# Altium schematic sheet P03_MCU.SchDoc
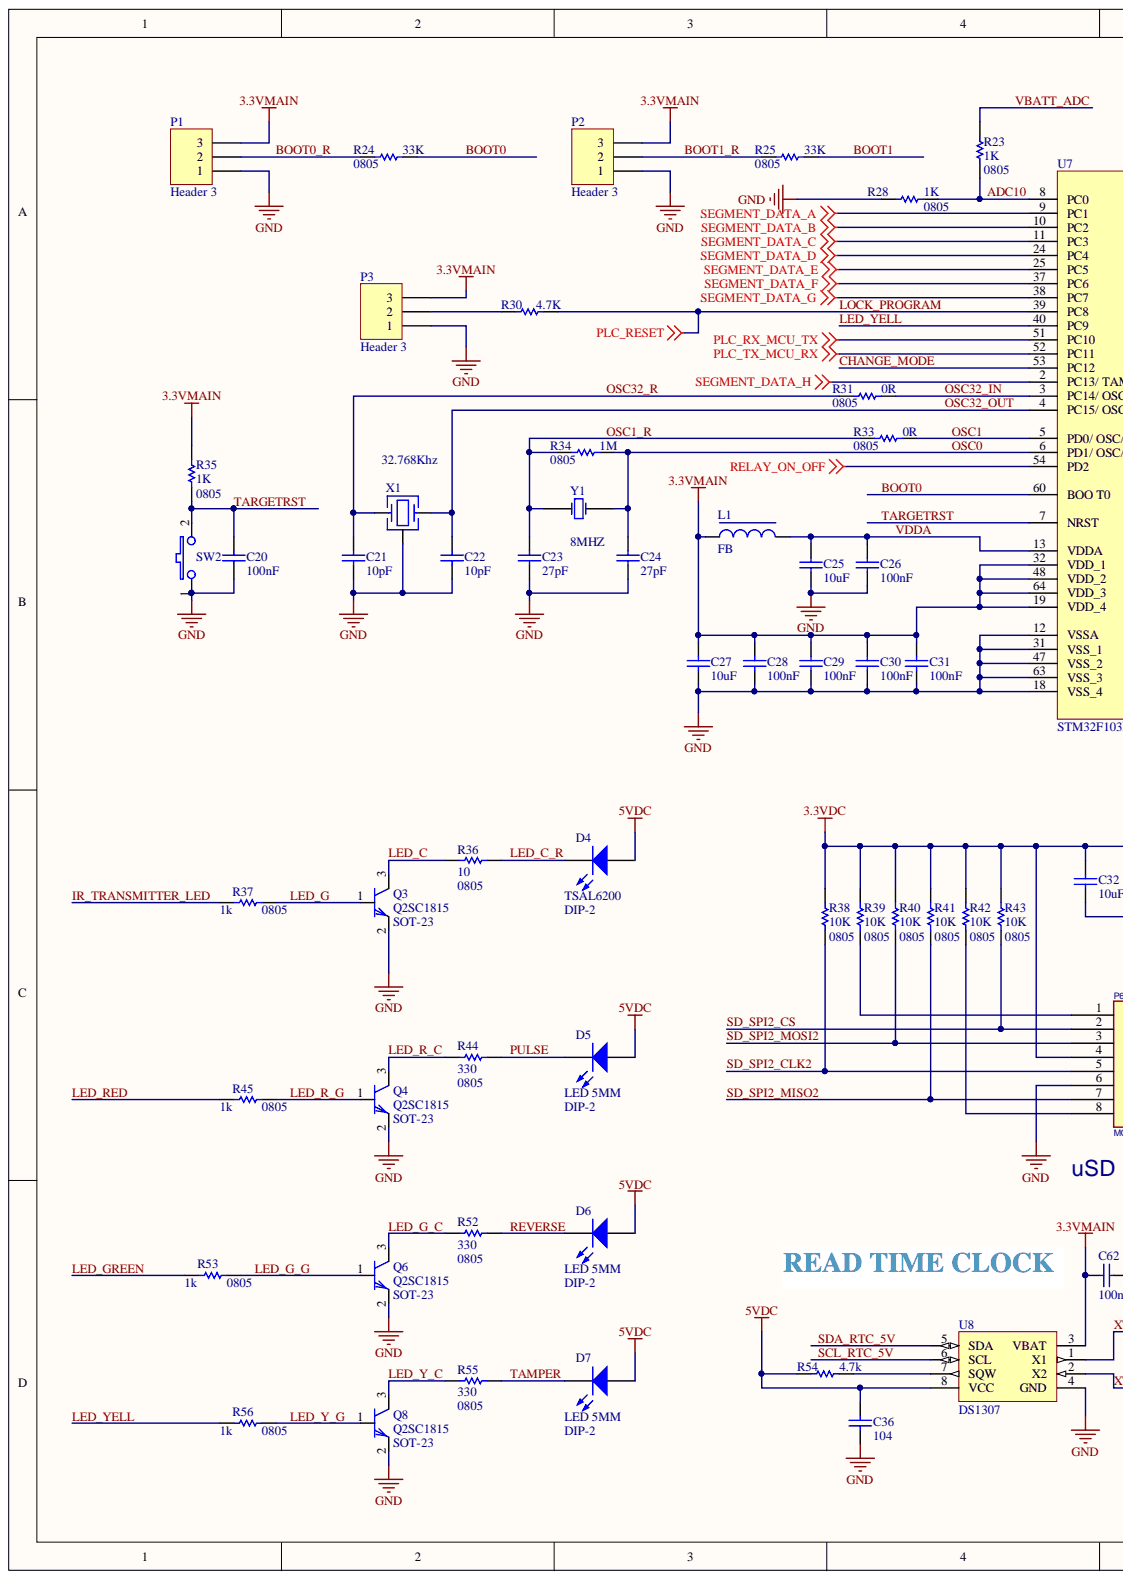
[diagram p0: P03_MCU.SchDoc, left]
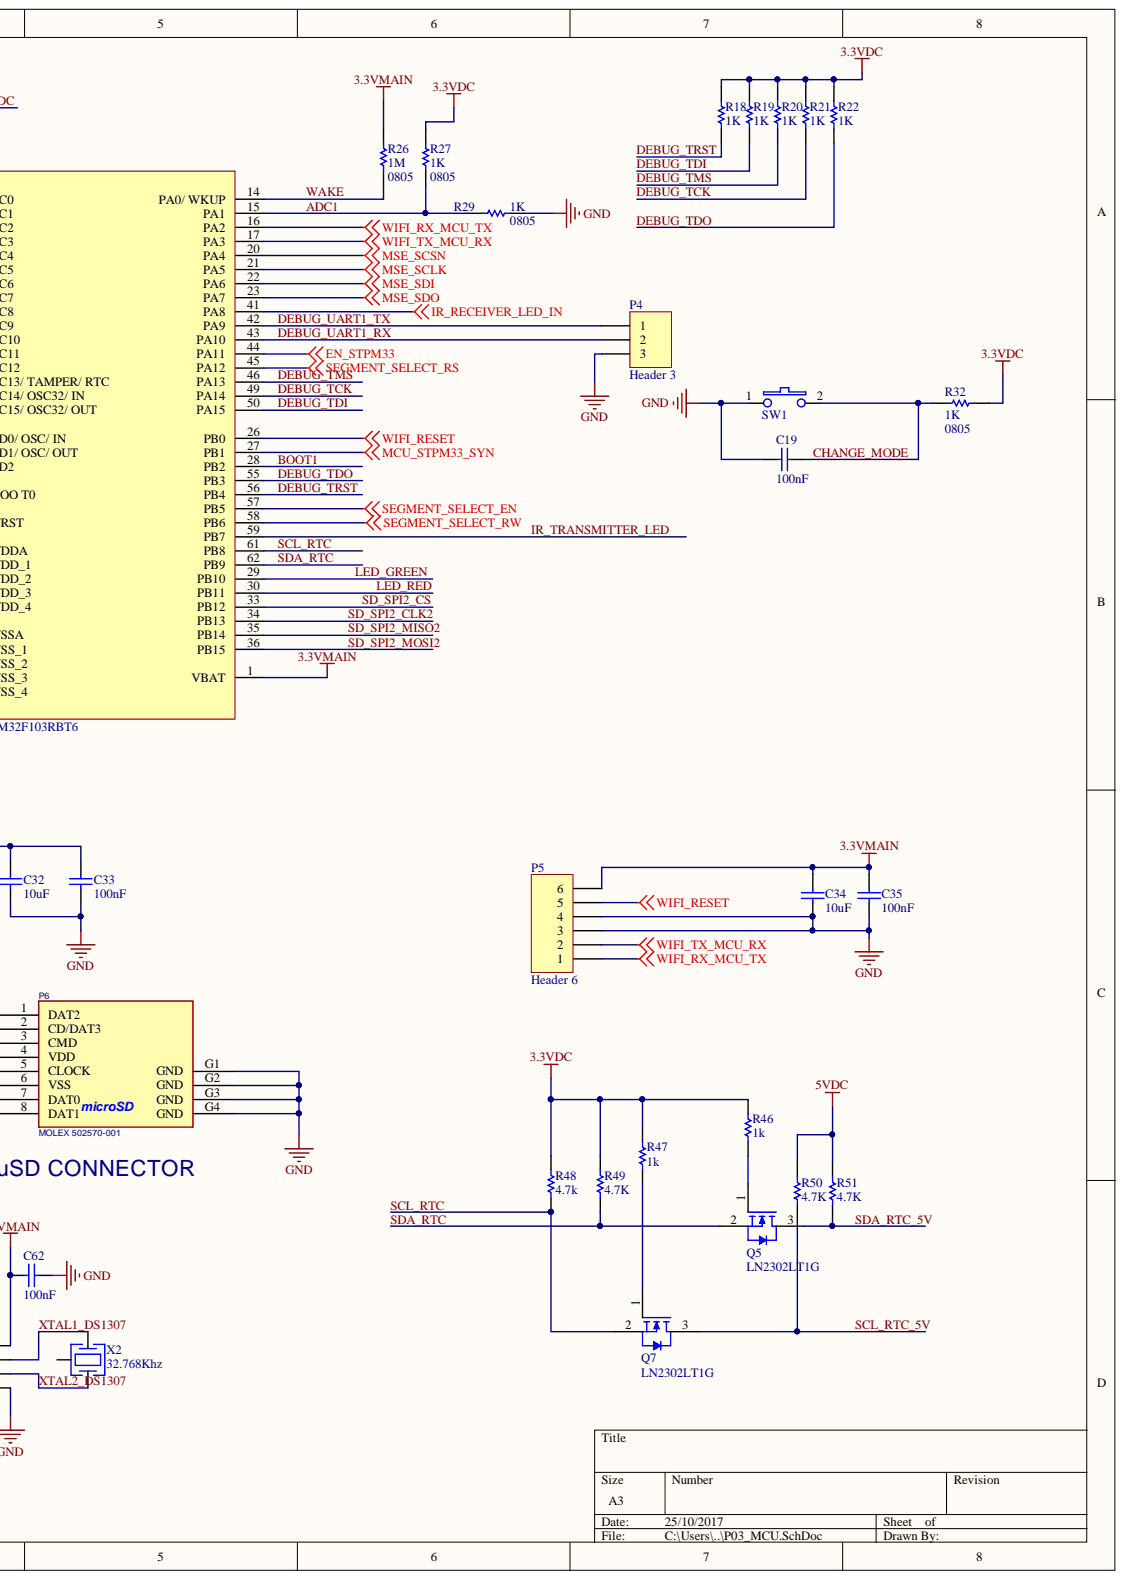
[diagram p1: P03_MCU.SchDoc, right]

## Parts
C19  100nF  [C-NP-0805]
C20  100nF  [C-NP-0805]
C21  10pF  [C-NP-0805]
C22  10pF  [C-NP-0805]
C23  27pF  [C-NP-0805]
C24  27pF  [C-NP-0805]
C25  10uF  [C-NP-0805]
C26  100nF  [C-NP-0805]
C27  10uF  [C-NP-0805]
C28  100nF  [C-NP-0805]
C29  100nF  [C-NP-0805]
C30  100nF  [C-NP-0805]
C31  100nF  [C-NP-0805]
C32  10uF  [C-NP-0805]
C33  100nF  [C-NP-0805]
C34  10uF  [C-NP-0805]
C35  100nF  [C-NP-0805]
C36  104  [C-NP-0805]
C62  100nF  [C-NP-0805]
D4  LED  Part: TSAL6200  PCB_Footprint: DIP-2  [LED-0 5mm BLUE]
D5  LED  Part: LED 5MM  PCB_Footprint: DIP-2  [LED-0 5mm RED]
D6  LED  Part: LED 5MM  PCB_Footprint: DIP-2  [LED-0 5mm ORANGE]
D7  LED  Part: LED 5MM  PCB_Footprint: DIP-2  [LED-0 5mm YELLOW]
L1  FB  [Res 10mm (1/2W)]
P1  Header 3  [Header 3]
P2  Header 3  [Header 3]
P3  Header 3  [Header 3]
P4  Header 3  [Header 3]
P5  Header 6  [Header 6]
P6  MOLEX 502570-001  [MicroSD_Socket]
Q3  C1815  [SOT-23]
Q4  C1815  [SOT-23]
Q5  LN2302LT1G  [SOT-23]
Q6  C1815  [SOT-23]
Q7  LN2302LT1G  [SOT-23]
Q8  C1815  [SOT-23]
R18  Part: 1K  [R-0805]  (hidden comment: 10k)
R19  1K  [R-0805]
R20  1K  [R-0805]
R21  1K  [R-0805]
R22  1K  [R-0805]
R23  1K  PCB_Footprint: 0805  [R-0805]
R24  33K  PCB_Footprint: 0805  [R-0805]
R25  33K  PCB_Footprint: 0805  [R-0805]
R26  1M  PCB_Footprint: 0805  [R-0805]
R27  1K  PCB_Footprint: 0805  [R-0805]
R28  1K  PCB_Footprint: 0805  [R-0805]
R29  1K  PCB_Footprint: 0805  [R-0805]
R30  4.7K  [R-0805]
R31  0R  PCB_Footprint: 0805  [R-0805]
R32  1K  PCB_Footprint: 0805  [R-0805]
R33  0R  PCB_Footprint: 0805  [R-0805]
R34  1M  PCB_Footprint: 0805  [R-0805]
R35  1K  PCB_Footprint: 0805  [R-0805]
R36  10  PCB_Footprint: 0805  [R-0805]
R37  1k  PCB_Footprint: 0805  [R-0805]
R38  10K  PCB_Footprint: 0805  [R-0805]
R39  10K  PCB_Footprint: 0805  [R-0805]
R40  10K  PCB_Footprint: 0805  [R-0805]
R41  10K  PCB_Footprint: 0805  [R-0805]
R42  10K  PCB_Footprint: 0805  [R-0805]
R43  10K  PCB_Footprint: 0805  [R-0805]
R44  330  PCB_Footprint: 0805  [R-0805]
R45  1k  PCB_Footprint: 0805  [R-0805]
R46  1k  [R-0805]
R47  1k  [R-0805]
R48  4.7k  [R-0805]
R49  4.7K  [R-0805]
R50  4.7K  [R-0805]
R51  4.7K  [R-0805]
R52  330  PCB_Footprint: 0805  [R-0805]
R53  1k  PCB_Footprint: 0805  [R-0805]
R54  4.7k  [R-0805]
R55  330  PCB_Footprint: 0805  [R-0805]
R56  1k  PCB_Footprint: 0805  [R-0805]
SW1  PTS635  [TactSwitches.PTS635.SMT]  (value not drawn)
SW2  PTS635  [TactSwitches.PTS635.SMT]  (value not drawn)
U7  STM32F103RBT6  [TQFP-PT64_N]
U8  DS1307  [SOIC8]
X1  32.768Khz  [Crystal_cylinder_SMD]
X2  32.768Khz  [Crystal_cylinder_SMD]
Y1  8MHZ  [CRYSTAL_SMD]

## Nets
3.3VDC: C32.2 C33.2 P6.4(VDD) R18.2 R19.2 R20.2 R21.2 R22.2 R27.2 R32.1 R38.1 R39.1 R40.1 R41.1 R42.1 R43.1 R46.2 R47.2 R48.1 R49.2
3.3VMAIN: C27.2 C28.2 C29.2 C30.2 C31.2 C34.2 C35.2 C62.2 L1.1 P1.3 P2.3 P3.3 P5.6 R26.1 R35.2 U7.1(VBAT) U7.19(VDD_4) U7.32(VDD_1) U7.48(VDD_2) U7.64(VDD_3) U8.3(VBAT)
5VDC: C36.1 D4.1 D5.1 D6.1 D7.1 R50.2 R51.2 R54.1 U8.8(VCC)
EN_STPM33: U7.44(PA11)
GND: C19.1 C20.2 C21.1 C22.1 C23.1 C24.1 C25.1 C26.1 C27.1 C28.1 C29.1 C30.1 C31.1 C32.1 C33.1 C34.1 C35.1 C36.2 C62.1 P1.1 P2.1 P3.1 P4.3 P5.3 P5.4 P6.6(VSS) P6.G1(GND) P6.G2(GND) P6.G3(GND) P6.G4(GND) Q3.2 Q4.2 Q6.2 Q8.2 R28.2 R29.1 SW1.1 SW2.1 U7.12(VSSA) U7.18(VSS_4) U7.31(VSS_1) U7.47(VSS_2) U7.63(VSS_3) U8.4(GND) X1.3
IR_RECEIVER_LED_IN: U7.41(PA8)
MCU_STPM33_SYN: U7.27(PB1)
MSE_SCLK: U7.21(PA5)
MSE_SCSN: U7.20(PA4)
MSE_SDI: U7.22(PA6)
MSE_SDO: U7.23(PA7)
PLC_RX_MCU_TX: U7.51(PC10)
PLC_TX_MCU_RX: U7.52(PC11)
RELAY_ON_OFF: U7.54(PD2)
SEGMENT_DATA_A: U7.9(PC1)
SEGMENT_DATA_B: U7.10(PC2)
SEGMENT_DATA_C: U7.11(PC3)
SEGMENT_DATA_D: U7.24(PC4)
SEGMENT_DATA_E: U7.25(PC5)
SEGMENT_DATA_F: U7.37(PC6)
SEGMENT_DATA_G: U7.38(PC7)
SEGMENT_DATA_H: U7.2(PC13/_TAMPER/_RTC)
SEGMENT_SELECT_EN: U7.57(PB5)
SEGMENT_SELECT_RS: U7.45(PA12)
SEGMENT_SELECT_RW: U7.58(PB6)
WIFI_RESET: P5.5 U7.26(PB0)
WIFI_RX_MCU_TX: P5.1 U7.16(PA2)
WIFI_TX_MCU_RX: P5.2 U7.17(PA3)
ADC1: R27.1 R29.2 U7.15(PA1)
ADC10: R23.1 R28.1 U7.8(PC0)
BOOT0: R24.2 U7.60(BOO_T0)
BOOT0_R: P1.2 R24.1
BOOT1: R25.2 U7.28(PB2)
BOOT1_R: P2.2 R25.1
CHANGE_MODE: C19.2 R32.2 SW1.2 U7.53(PC12)
DEBUG_TCK: R21.1 U7.49(PA14)
DEBUG_TDI: R19.1 U7.50(PA15)
DEBUG_TDO: R22.1 U7.55(PB3)
DEBUG_TMS: R20.1 U7.46(PA13)
DEBUG_TRST: R18.1 U7.56(PB4)
DEBUG_UART1_RX: P4.2 U7.43(PA10)
DEBUG_UART1_TX: P4.1 U7.42(PA9)
IR_TRANSMITTER_LED: R37.1 U7.59(PB7)
LED_C: Q3.3 R36.2
LED_C_R: D4.2 R36.1
LED_G: Q3.1 R37.2
LED_G_C: Q6.3 R52.2
LED_G_G: Q6.1 R53.2
LED_GREEN: R53.1 U7.29(PB10)
LED_R_C: Q4.3 R44.2
LED_R_G: Q4.1 R45.2
LED_RED: R45.1 U7.30(PB11)
LED_Y_C: Q8.3 R55.2
LED_Y_G: Q8.1 R56.2
LED_YELL: R56.1 U7.40(PC9)
LOCK_PROGRAM: R30.2 U7.39(PC8)
OSC0: C24.2 R34.2 U7.6(PD1/_OSC/_OUT) Y1.1
OSC1: R33.2 U7.5(PD0/_OSC/_IN)
OSC1_R: C23.2 R33.1 R34.1 Y1.2
OSC32_IN: R31.2 U7.3(PC14/_OSC32/_IN)
OSC32_OUT: C22.2 U7.4(PC15/_OSC32/_OUT) X1.2
OSC32_R: C21.2 R31.1 X1.1
PULSE: D5.2 R44.1
REVERSE: D6.2 R52.1
SCL_RTC: Q7.2 R48.2 U7.61(PB8)
SCL_RTC_5V: Q7.3 R50.1 U8.6(SCL)
SD_SPI2_CLK2: P6.5(CLOCK) R38.2 U7.34(PB13)
SD_SPI2_CS: P6.2(CD/DAT3) R43.2 U7.33(PB12)
SD_SPI2_MISO2: P6.7(DAT0) R41.2 U7.35(PB14)
SD_SPI2_MOSI2: P6.3(CMD) R40.2 U7.36(PB15)
SDA_RTC: Q5.2 R49.1 U7.62(PB9)
SDA_RTC_5V: Q5.3 R51.1 U8.5(SDA)
TAMPER: D7.2 R55.1
TARGETRST: C20.1 R35.1 SW2.2 U7.7(NRST)
VBATT_ADC: R23.2
VDDA: C25.2 C26.2 L1.2 U7.13(VDDA)
WAKE: R26.2 U7.14(PA0/_WKUP)
XTAL1_DS1307: U8.1(X1) X2.2
XTAL2_DS1307: U8.2(X2) X2.1
NetP3_2: P3.2 R30.1
NetP6_1: P6.1(DAT2) R39.2
NetP6_8: P6.8(DAT1) R42.2
NetQ5_1: Q5.1 R46.1
NetQ7_1: Q7.1 R47.1
NetR54_2: R54.2 U8.7(SQW)

Not connected: X2 3

## Library data of the parts
C19 C20 C25 C27 C32 C34 = 100nF-50V-C0805: Non-Polarized Capacitor; Manufacturer: Yageo; Manufacturer Part Number: CC0805KRX7R9BB104; Value: 1uF; Component Kind: Standard; Digi-Key Part Number: 311-1140-1-ND; Library Name: Capacitor.SchLib; Unit Price: 0.1
C21 C22 C26 C28-C31 C33 C35 C62 = 100nF-50V-C0805: Non-Polarized Capacitor; Manufacturer: Yageo; Manufacturer Part Number: CC0805KRX7R9BB104; Component Kind: Standard; Digi-Key Part Number: 311-1140-1-ND; Library Name: Capacitor.SchLib; Unit Price: 0.1
  C21 C22: Value: 10pF
  C26 C28-C31 C33 C35 C62: Value: 100nF
C23 C24 = 100nF-50V-C0805: Non-Polarized Capacitor; Manufacturer: Yageo; Manufacturer Part Number: CC0805KRX7R9BB104; Value: 10pF; Component Kind: Standard; Digi-Key Part Number: 311-1140-1-ND; Library Name: Capacitor.SchLib; Unit Price: 0.1
C36 = Cap Non-pol: Non-polarized Capacitor; Component Kind: Standard; LatestRevisionDate: 17-Jul-2002; LatestRevisionNote: Re-released for DXP Platform.; PackageReference: RAD-0.3; Published: 8-Jun-2000; Value: 104; Library Name: hphonglib.SchLib; Publisher: Altium Limited
D4-D7 = LED: Typical LED; Component Kind: Standard; Library Name: hphonglib.SchLib
L1 = Inductor Ferrite: Cuon Cam L; Code_IEC: 1005; Vendor: Digikey; Code_JEITA: 0402; Component Kind: Standard; ComponentLink1Description: Digikey Part Link; ComponentLink2Description: Open Datasheet; LatestRevisionDate: 17-Jul-2002; LatestRevisionNote: Re-released for DXP Platform.; PackageReference: 0402-A; Published: 8-Jun-2000; Signal Integrity: Inductor; ComponentLink2URL: H:\LIBRARY\LIBRARY.Datasheet\; Library Name: hphonglib.SchLib; Publisher: Altium Limited
P1-P4 = Header 3: Header, 3-Pin; Component Kind: Standard; LatestRevisionDate: 17-Jul-2002; LatestRevisionNote: Re-released for DXP Platform.; Library Name: hphonglib.SchLib; Publisher: Altium Limited
P5 = Header 6: Header, 6-Pin; Component Kind: Standard; LatestRevisionDate: 17-Jul-2002; LatestRevisionNote: Re-released for DXP Platform.; Library Name: hphonglib.SchLib; Publisher: Altium Limited
P6 = microSD_CONN_2: CONN MICRO SD R/A PUSH-PUSH SMD; Manufacturer: Molex Inc; Manufacturer Part Number: 5025700893; Card Type: Secure Digital - microSD™ TransFlash; Connector Type: Connector and Ejector; Insertion, Removal Method: Push In, Push Out; Number of Positions: 10 (8 + 2); Category: Connectors, Interconnects; Component Kind: Standard; ComponentLink1Description: http://www.molex.com/pdm_docs/sd/5025700893_sd.pdf; ComponentLink1URL: http://www.molex.com/pdm_docs/sd/5025700893_sd.pdf; Contact Finish: Gold; Contact Finish Thickness: 20µin (0.51µm); Ejector Side: -; Features: Switch; Height Above Board: 0.071" (1.80mm); Mounting Feature: Normal, Standard - Top; Mounting Type: Surface Mount, Right Angle; Packaging: Tape & Reel (TR); Pricing 1: 1300=2.16645, 2600=2.01703, 6500=1.94233 (USD); RoHS: RoHS Compliant; Stock 1: 16900; Supplier 1: Digi-Key; Supplier Part Number 1: WM19093TR-ND; Library Name: BEAGLEBONEBLACK_REV A5B.SCHLIB
Q3 Q4 Q6 Q8 = C1815: Q2SC1815; Component Kind: Standard; Part: Q2SC1815; PCB_Footprint: SOT-23; Simulation: Q2SC1815; Library Name: hphonglib.SchLib
Q5 Q7 = 2N7002: N-Channel Enhancement Mode FET; Vendor: Digikey; Component Kind: Standard; ComponentLink1Description: Digikey Part Link; ComponentLink2Description: Open Datasheet; DatasheetDocument: Rev A1, 1997; Published: 5-Jan-2004; ComponentLink2URL: H:\LIBRARY\LIBRARY.Datasheet\; Library Name: hphonglib.SchLib; Publisher: Altium Limited
R18-R56 = Resistor: Component Kind: Standard; Library Name: hphonglib.SchLib
  R19-R23 R27-R29 R32 R35: Part: 1K
  R24 R25: Part: 33K
  R26 R34: Part: 1M
  R30 R49-R51: Description: Resistor; LatestRevisionDate: 17-Jul-2002; LatestRevisionNote: Re-released for DXP Platform.; PackageReference: AXIAL-0.3; Published: 8-Jun-2000; Value: 4.7K
  R31 R33: Part: 0R
  R36: Part: 10
  R37 R45 R53 R56: Part: 1k
  R38-R43: Part: 10K
  R44 R52 R55: Part: 330
  R46 R47: Description: Resistor; LatestRevisionDate: 17-Jul-2002; LatestRevisionNote: Re-released for DXP Platform.; PackageReference: AXIAL-0.3; Published: 8-Jun-2000; Value: 1k
  R48 R54: Description: Resistor; LatestRevisionDate: 17-Jul-2002; LatestRevisionNote: Re-released for DXP Platform.; PackageReference: AXIAL-0.3; Published: 8-Jun-2000; Value: 4.7k
  R30 R46-R51 R54: Publisher: Altium Limited
SW1 SW2 = SW TACT MOM SPST: SWITCH TACT MOM SPST SMD; Manufacturer: C&K Components; Manufacturer P/N: PTS635SL25SMTR LFS; Vendor: Digikey; Vendor P/N: CKN9119CT-ND; Component Kind: Standard; ComponentLink1Description: Digikey Part Link; ComponentLink1URL: http://search.digikey.com/scripts/DkSearch/dksus.dll?Detail&name=CKN1573CT-ND; ComponentLink2Description: Open Datasheet; Published: 13-April-2009; ComponentLink2URL: H:\LIBRARY\LIBRARY.Datasheet\SBLCDA4_Specification.pdf; Library Name: hphonglib.SchLib; Publisher: Hoang Nguyen
U8 = DS1307: Manufacturer: Maxim; Component Kind: Standard; ComponentLink1Description: Digikey Part Link; ComponentLink2Description: Open Datasheet; Description: DS1307; ComponentLink2URL: H:\LIBRARY\LIBRARY.Datasheet\DS1307.pdf; Library Name: hphonglib.SchLib
X1 X2 = Crystal1: Tuning Forl Crystal Units (SMD-Cylinder Type); Vendor: Digikey; Component Kind: Standard; ComponentLink1Description: Digikey Part Link; ComponentLink1URL: http://search.digikey.com/scripts/DkSearch/dksus.dll?Detail&name=300-2066-1-ND; ComponentLink2Description: Open Datasheet; Published: 13-April-2009; Value: 32.768Khz; ComponentLink2URL: H:\LIBRARY\LIBRARY.Datasheet\k-cmr.pdf; Library Name: hphonglib.SchLib; Publisher: Hoang Nguyen
Y1 = XTAL: Crystal Oscillator; Component Kind: Standard; LatestRevisionDate: 17-Jul-2002; LatestRevisionNote: Re-released for DXP Platform.; PackageReference: R38; Published: 8-Jun-2000; VALUE: 8MHZ; Library Name: hphonglib.SchLib; Publisher: Altium Limited
U7 = STM32F103RBT6: Component Kind: Standard; Library Name: HuySch.SchLib
